# Altium schematic sheet TOP.SchDoc(TOP)
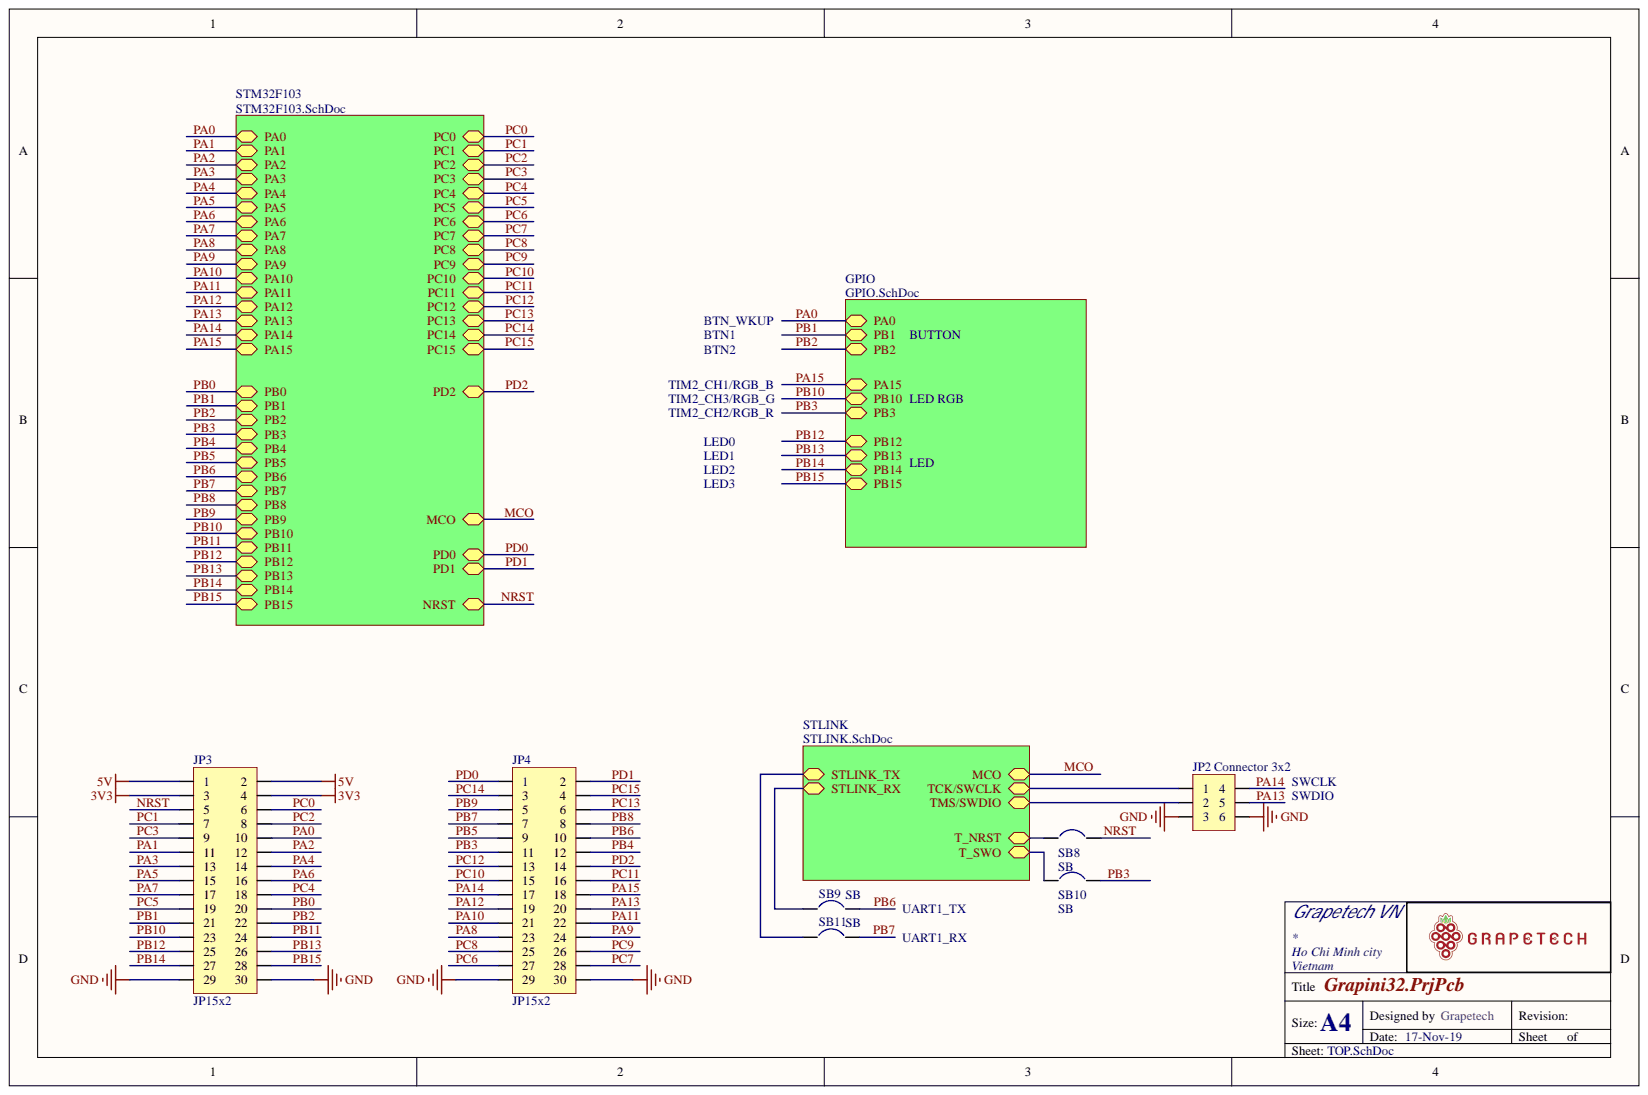
[diagram p0: TOP.SchDoc]

## Parts
JP2  Connector 3x2  [JP3x2]
JP3  JP15x2  [JP15x2]
JP4  JP15x2  [JP15x2]
SB8  SB  [SB0603]
SB9  SB  [SB0603]
SB10  SB  [SB0603]
SB11  SB  [SB0603]

## Nets
3V3: JP3.3 JP3.4
5V: JP3.1 JP3.2
GND: JP2.3 JP2.6 JP3.29 JP3.30 JP4.29 JP4.30
NRST: JP3.5 SB8.2
PA0: JP3.10
PA1: JP3.11
PA2: JP3.12
PA3: JP3.13
PA4: JP3.14
PA5: JP3.15
PA6: JP3.16
PA7: JP3.17
PA8: JP4.23
PA9: JP4.24
PA10: JP4.21
PA11: JP4.22
PA12: JP4.19
PA13: JP2.5 JP4.20
PA14: JP2.4 JP4.17
PA15: JP4.18
PB0: JP3.20
PB1: JP3.21
PB2: JP3.22
PB3: JP4.11 SB10.2
PB4: JP4.12
PB5: JP4.9
PB6: JP4.10 SB9.2
PB7: JP4.7 SB11.2
PB8: JP4.8
PB9: JP4.5
PB10: JP3.23
PB11: JP3.24
PB12: JP3.25
PB13: JP3.26
PB14: JP3.27
PB15: JP3.28
PC0: JP3.6
PC1: JP3.7
PC2: JP3.8
PC3: JP3.9
PC4: JP3.18
PC5: JP3.19
PC6: JP4.27
PC7: JP4.28
PC8: JP4.25
PC9: JP4.26
PC10: JP4.15
PC11: JP4.16
PC12: JP4.13
PC13: JP4.6
PC14: JP4.3
PC15: JP4.4
PD0: JP4.1
PD1: JP4.2
PD2: JP4.14
NetJP2_1: JP2.1
NetJP2_2: JP2.2
NetSB8_1: SB8.1
NetSB9_1: SB9.1
NetSB10_1: SB10.1
NetSB11_1: SB11.1
MCO:

## Library data of the parts
JP2 = JP3x2: Value: Connector 3x2; Library Name: MyLib.SchLib; Description: Connector 3x2; Component Kind: Standard
JP3 JP4 = JP15x2: 2.54mm pitch, 15x2 pin Connect sil female; Value: JP11; Library Name: MyLib.SchLib; Component Kind: Standard
SB8-SB11 = SB: Library Name: MyLib.SchLib; Component Kind: Standard
